# Altium schematic sheet ARK_CAN_GPS_External_Interfaces_Power.SchDoc(ARK_CAN_GPS_External_Interfaces_Power)
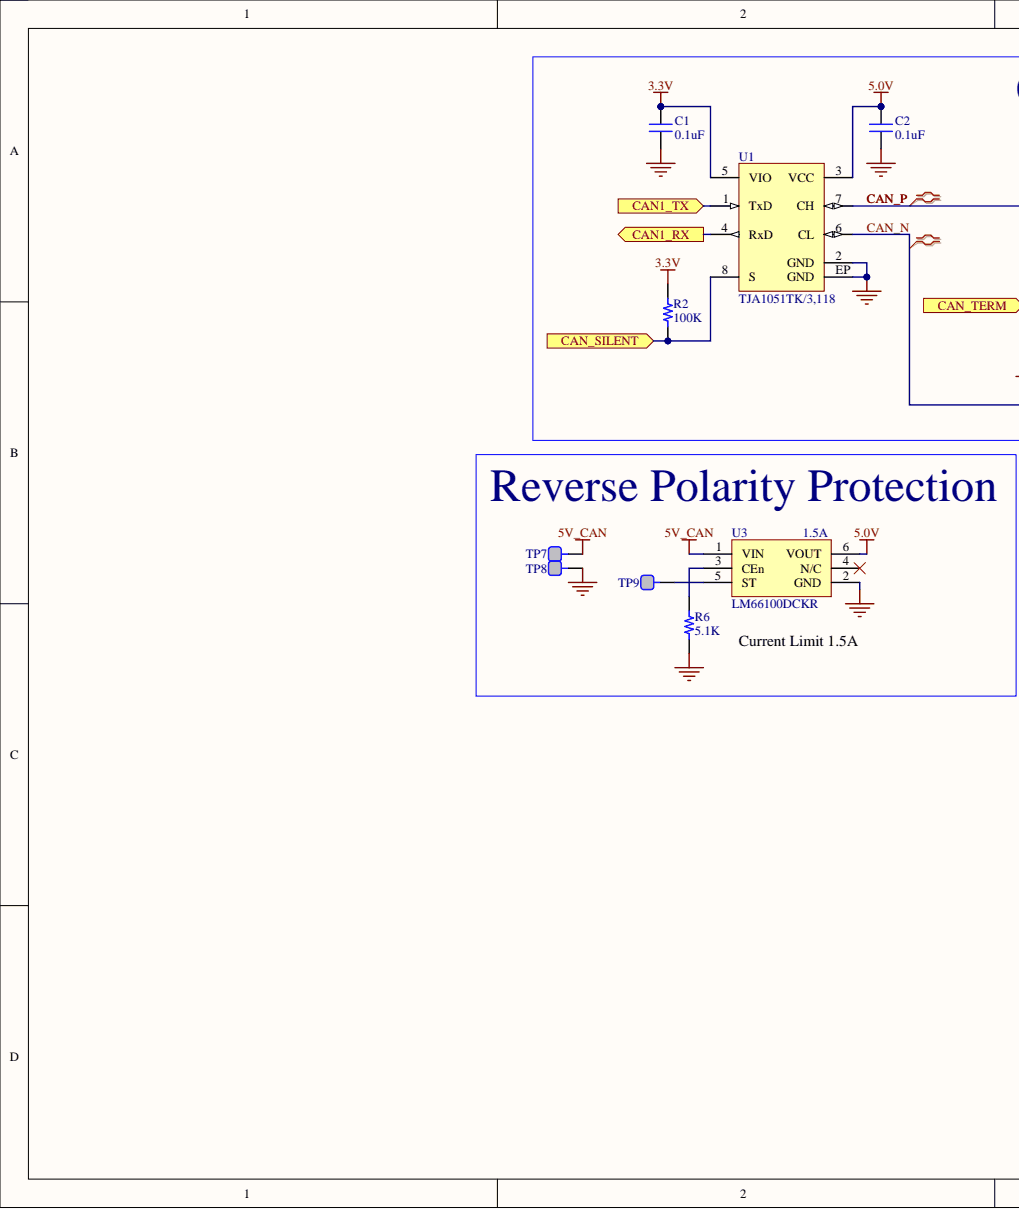
[diagram p0: ARK_CAN_GPS_External_Interfaces_Power.SchDoc, left]
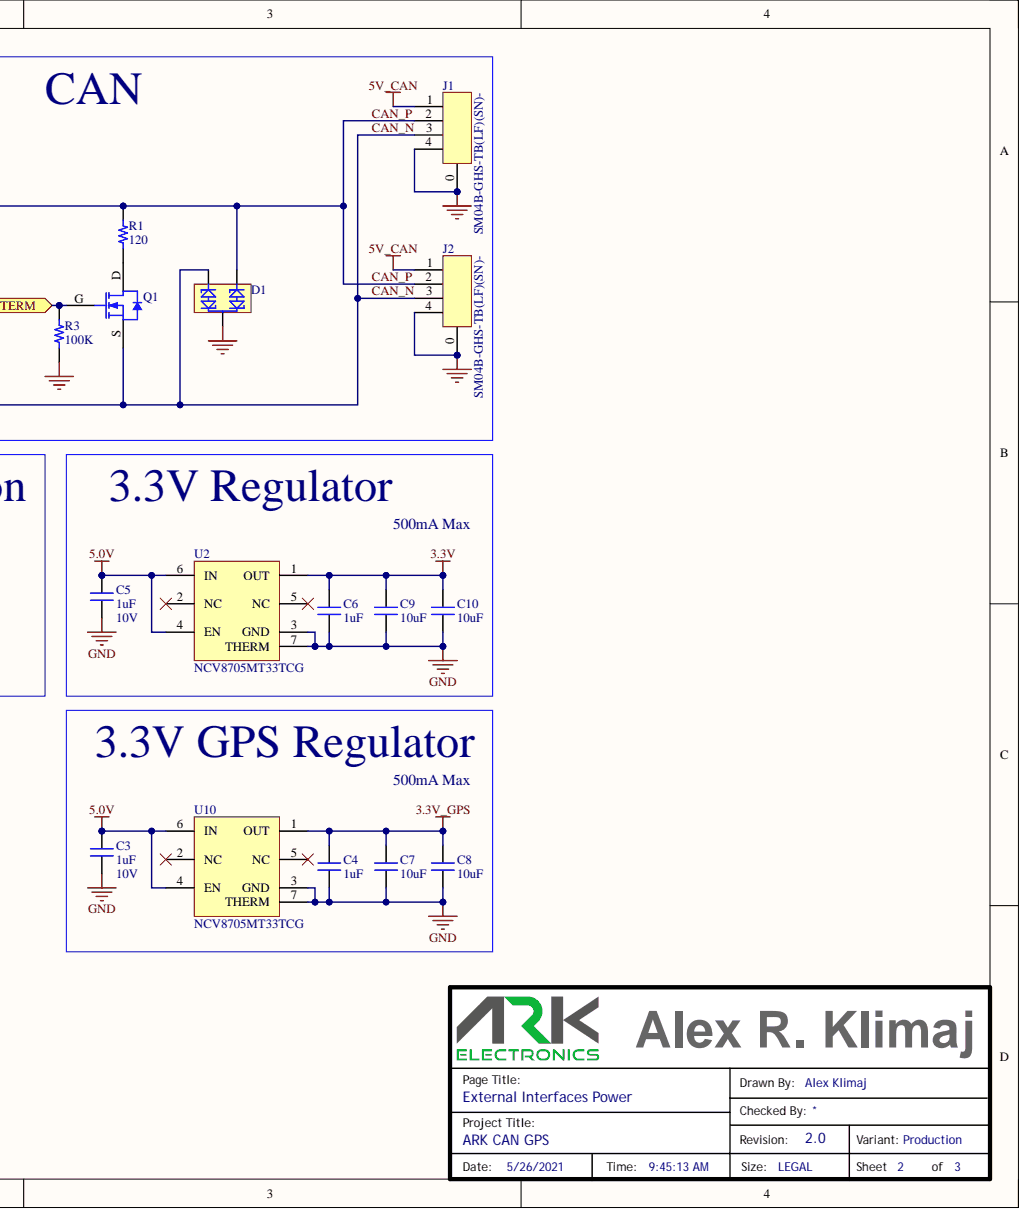
[diagram p1: ARK_CAN_GPS_External_Interfaces_Power.SchDoc, right]

## Parts
C1  value 0.1uF  [CAP 0402/1005]  (hidden comment: Capacitor)
C2  value 0.1uF  [CAP 0402/1005]  (hidden comment: Capacitor)
C3  1uF  Voltage_Rating: 10V  [CAP 0402/1005]
C4  1uF  [CAP 0402/1005]
C5  1uF  Voltage_Rating: 10V  [CAP 0402/1005]
C6  1uF  [CAP 0402/1005]
C7  10uF  [CAP 0402/1005]
C8  10uF  [CAP 0402/1005]
C9  10uF  [CAP 0402/1005]
C10  10uF  [CAP 0402/1005]
D1  PESD1CAN-UX  [SOT65P210X110-3N]  (value not drawn)
J1  SM04B-GHS  value SM04B-GHS-TB(LF)(SN)-  Mfr Part Number: SM04B-GHS-TB(LF)(SN)-  [SM04B-GHS]
J2  SM04B-GHS  value SM04B-GHS-TB(LF)(SN)-  Mfr Part Number: SM04B-GHS-TB(LF)(SN)-  [SM04B-GHS]
Q1  PMV130ENEAR  [SOT23 DIODES]  (value not drawn)
R1  120  [RES603L]
R2  100K  [RESC0402(1005)_N]
R3  100K  [RESC0402(1005)_N]
R6  value 5.1K  [RESC0402(1005)_N]  (hidden comment: Resistor)
TP7  TEST_PAD  [TEST_PAD]  (value not drawn)  (no BOM)
TP8  TEST_PAD  [TEST_PAD]  (value not drawn)  (no BOM)
TP9  TEST_PAD  [TEST_PAD]  (value not drawn)  (no BOM)
U1  TJA1051TK/3,118  [8DFN_3x3]
U2  NCV8705MT33TCG  [WDFN6 2x2, 0.65P]
U3  LM66100  value LM66100DCKR  Current_Rating: 1.5A  Mfr Part Number: LM66100DCKR  [SOT65P210X110-6N]
U10  NCV8705MT33TCG  [WDFN6 2x2, 0.65P]

## Ports
CAN1_RX, CAN1_TX, CAN_SILENT, CAN_TERM

## Nets
3.3V: C1.2 C6.2 C9.2 C10.2 R2.2 U1.5(VIO) U2.1(OUT)
3.3V_GPS: C4.2 C7.2 C8.2 U10.1(OUT)
5.0V: C2.2 C3.2 C5.2 J1.1 J2.1 TP7.1 U1.3(VCC) U2.4(EN) U2.6(IN) U3.1(VIN) U3.6(VOUT) U10.4(EN) U10.6(IN)
GND: C1.1 C2.1 C3.1 C4.1 C5.1 C6.1 C7.1 C8.1 C9.1 C10.1 D1.3 J1.0 J1.4 J2.0 J2.4 R3.1 R6.2 TP8.1 U1.2(GND) U1.EP(GND) U2.3(GND) U2.7(THERM) U3.2(GND) U10.3(GND) U10.7(THERM)
CAN1_RX: U1.4(RxD) ; port CAN1_RX
CAN1_TX: U1.1(TxD) ; port CAN1_TX
CAN_N: D1.1 J1.3 J2.3 Q1.S U1.6(CL)
CAN_P: D1.2 J1.2 J2.2 R1.2 U1.7(CH)
CAN_SILENT: R2.1 U1.8(S) ; port CAN_SILENT
CAN_TERM: Q1.G R3.2 ; port CAN_TERM
NetQ1_D: Q1.D R1.1
NetR6_1: R6.1 U3.3(CEn)
NetTP9_1: TP9.1 U3.5(ST)

Not connected: U2 2(NC) 5(NC); U3 4(N/C); U10 2(NC) 5(NC)

## Library data of the parts
C1 C2 = 30303: SMT Capacitor; Manufacturer: Samsung Electro-Mechanics; Mfr Part Description: CAP CER 0.1uF ±10% 10V X5R 0402 (1005 Metric); Mfr Part Number: CL05A104KP5NNNC; Database Table Name: Parts; Dielectric: X5R; Tolerance: ±10%; Updated By: aklimaj; Voltage_Rating: 10V; Component Kind: Standard; ComponentLink1Description: Datasheet; ComponentLink1URL: http://www.samsungsem.com/kr/support/product-search/mlcc/CL05A104KP5NNNC.jsp; ComponentLink2Description: Supplier Part Details; ComponentLink2URL: https://www.digikey.com/product-detail/en/samsung-electro-mechanics/CL05A104KP5NNNC/1276-1022-1-ND/3889108; Created_Date: 2019/02/28 17:08:36; ID: 30303; Library Name: Parts.DbLib; LifeCycle: New; Package_Case: 0402 (1005 Metric); Part_Type: Capacitor; RoHS: Yes; Supplier: Digi-Key; Supplier Part Number: 1276-1022-1-ND; Temperature Range: -55C to +85C; Updated_Date: 2019/02/28 17:09:37; Created By: aklimaj
C3 C5 = 30652: SMT Capacitor; Manufacturer: Samsung Electro-Mechanics; Mfr Part Description: CAP CER 1uF ±10% 10V X5R 0402 (1005 Metric); Mfr Part Number: CL05A105KP5NNNC; Database Table Name: Parts; Dielectric: X5R; Tolerance: ±10%; Updated By: aklimaj; Component Kind: Standard; ComponentLink1Description: Datasheet; ComponentLink1URL: http://www.samsungsem.com/kr/support/product-search/mlcc/CL05A105KP5NNNC.jsp; ComponentLink2Description: Supplier Part Details; ComponentLink2URL: https://www.digikey.com/product-detail/en/0/1276-1076-1-ND; Created_Date: 2019/11/21 13:38:55; ID: 30652; Library Name: Parts.DbLib; LifeCycle: New; Package_Case: 0402 (1005 Metric); Part_Type: Capacitor; RoHS: Yes; Supplier: Digi-Key; Supplier Part Number: 1276-1076-1-ND; Temperature Range: -55C to +85C; Updated_Date: 2020/08/31 12:38:02; Value: 1uF; Created By: aklimaj
C4 C6 = 30652: SMT Capacitor; Manufacturer: Samsung Electro-Mechanics; Mfr Part Description: CAP CER 1uF ±10% 10V X5R 0402 (1005 Metric); Mfr Part Number: CL05A105KP5NNNC; Database Table Name: Parts; Dielectric: X5R; Tolerance: ±10%; Updated By: aklimaj; Voltage_Rating: 10V; Component Kind: Standard; ComponentLink1Description: Datasheet; ComponentLink1URL: http://www.samsungsem.com/kr/support/product-search/mlcc/CL05A105KP5NNNC.jsp; ComponentLink2Description: Supplier Part Details; ComponentLink2URL: https://www.digikey.com/product-detail/en/0/1276-1076-1-ND; Created_Date: 2019/11/21 13:38:55; ID: 30652; Library Name: Parts.DbLib; LifeCycle: New; Package_Case: 0402 (1005 Metric); Part_Type: Capacitor; RoHS: Yes; Supplier: Digi-Key; Supplier Part Number: 1276-1076-1-ND; Temperature Range: -55C to +85C; Updated_Date: 2020/08/31 12:38:02; Value: 1uF; Created By: aklimaj
C7-C10 = 30418: SMT Capacitor; Manufacturer: Samsung Electro-Mechanics; Mfr Part Description: CAP CER 10uF ±20% 10V X5R 0402 (1005 Metric); Mfr Part Number: CL05A106MP8NUB8; Database Table Name: Parts; Dielectric: X5R; Tolerance: ±20%; Updated By: aklimaj; Voltage_Rating: 10V; Component Kind: Standard; ComponentLink1Description: Datasheet; ComponentLink1URL: http://www.samsungsem.com/kr/support/product-search/mlcc/CL05A106MP8NUB8.jsp; ComponentLink2Description: Supplier Part Details; ComponentLink2URL: https://www.digikey.com/product-detail/en/samsung-electro-mechanics/CL05A106MP8NUB8/1276-6830-1-ND/5961689; Created_Date: 2019/04/03 13:12:18; ID: 30418; Library Name: Parts.DbLib; LifeCycle: New; Package_Case: 0402 (1005 Metric); Part_Type: Capacitor; RoHS: Yes; Supplier: Digi-Key; Supplier Part Number: 1276-6830-1-ND; Temperature Range: -55C to +85C; Updated_Date: 2019/04/03 13:12:36; Value: 10uF; Created By: aklimaj
D1 = 30765: Manufacturer: Nexperia USA Inc.; Mfr Part Description: TVS DIODE 24V 50V SC70-3; Part_Type: Protection; Current_Rating: 3A (8/20µs); Database Table Name: Parts; Updated By: aklimaj; Voltage_Rating: 24V (Max); Component Kind: Standard; ComponentLink1Description: Datasheet; ComponentLink1URL: https://assets.nexperia.com/documents/data-sheet/PESD1CAN-U.pdf; ComponentLink2Description: Supplier Part Details; ComponentLink2URL: https://www.digikey.com/product-detail/en/nexperia-usa-inc/PESD1CAN-UX/1727-1306-1-ND/4386316; Created_Date: 2020/04/14 12:26:16; ID: 30765; Library Name: Parts.DbLib; LifeCycle: New; Mfr Part Number: PESD1CAN-UX; Package_Case: SC-70, SOT-323; RoHS: Yes; Supplier: Digi-Key; Supplier Part Number: 1727-1306-1-ND; Temperature Range: -55C to +150C (TA); Updated_Date: 2020/04/14 12:34:02; Value: PESD1CAN-UX; Created By: aklimaj
J1 J2 = 30106: CONN HEADER GH SIDE 4POS 1.25MM; Manufacturer: JST Sales America Inc.; Part_Type: Connector; Current_Rating: 1A; Database Table Name: Parts; Updated By: aklimaj; Voltage_Rating: 50V; Component Kind: Standard; ComponentLink1Description: Datasheet; ComponentLink1URL: http://www.jst-mfg.com/product/pdf/eng/eGH.pdf; ComponentLink2Description: Supplier Part Details; ComponentLink2URL: https://www.digikey.com/product-detail/en/jst-sales-america-inc/SM04B-GHS-TB-LF-SN/455-1566-1-ND/807834; Created_Date: 2017/09/21 14:10:07; ID: 30106; Library Name: Parts.DbLib; LifeCycle: New; Mfr Part Description: CONN HEADER GH SIDE 4POS 1.25MM; RoHS: Yes; Supplier: Digi-Key; Supplier Part Number: 455-1566-1-ND; Temperature Range: -25C to +85C; Updated_Date: 2018/10/19 21:38:27; Created By: aklimaj
Q1 = 31093: Manufacturer: Nexperia USA Inc.; Mfr Part Description: MOSFET N-CH 40V 2.1A TO236AB; Part_Type: Discrete; Current_Rating: 2.1A (Ta); Database Table Name: Parts; Updated By: aklimaj; Voltage_Rating: 4.5V, 10V; Component Kind: Standard; ComponentLink1Description: Datasheet; ComponentLink1URL: https://assets.nexperia.com/documents/data-sheet/PMV130ENEA.pdf; ComponentLink2Description: Supplier Part Details; ComponentLink2URL: https://www.digikey.com/en/products/detail/nexperia-usa-inc/PMV130ENEAR/5395790; Created_Date: 2021/05/26 09:10:32; ID: 31093; Library Name: Parts.DbLib; LifeCycle: New; Mfr Part Number: PMV130ENEAR; Package_Case: TO-236-3, SC-59, SOT-23-3; RoHS: Yes; Supplier: Digi-Key; Supplier Part Number: 1727-2299-2-ND; Temperature Range: -55C to +150C (TJ); Updated_Date: 2021/05/26 09:10:42; Value: PMV130ENEAR; Created By: aklimaj
R1 = 30956: SMT Resistor; Manufacturer: Stackpole Electronics Inc; Mfr Part Description: RES 120 Ohm ±1% 1/10W 0603 (1608 Metric); Mfr Part Number: RMCF0603FT120R; Database Table Name: Parts; Power_Rating: 0.1W, 1/10W; Temperature Coeff: ±100ppm/degC; Tolerance: ±1%; Updated By: aklimaj; Component Kind: Standard; ComponentLink1Description: Datasheet; ComponentLink1URL: https://www.seielect.com/catalog/sei-rmcf_rmcp.pdf; ComponentLink2Description: Supplier Part Details; ComponentLink2URL: https://www.digikey.com/products/en?keywords=RMCF0603FT120R; Created_Date: 2020/12/23 11:13:51; ID: 30956; Library Name: Parts.DbLib; LifeCycle: New; Package_Case: 0603 (1608 Metric); Part_Type: Resistor; RoHS: Yes; Supplier: Digi-Key; Supplier Part Number: RMCF0603FT120RTR-ND; Temperature Range: -55C to +155C; Updated_Date: 2020/12/23 11:14:13; Value: 120; Created By: aklimaj
R2 R3 = 30333: SMT Resistor; Manufacturer: Yageo; Mfr Part Description: RES 100K Ohm ±5% 1/16W 0402 (1005 Metric); Mfr Part Number: AC0402JR-07100KL; Database Table Name: Parts; Power_Rating: 0.063W, 1/16W; Temperature Coeff: ±100ppm/degC; Tolerance: ±5%; Updated By: aklimaj; Component Kind: Standard; ComponentLink1Description: Datasheet; ComponentLink1URL: http://www.yageo.com/NewPortal/yageodocoutput?fileName=/pdf/R-Chip/PYu-AC_51_RoHS_L_6.pdf; ComponentLink2Description: Supplier Part Details; ComponentLink2URL: https://www.digikey.com/products/en?keywords=YAG3515CT-ND&stock=1; Created_Date: 2019/02/28 18:55:55; ID: 30333; Library Name: Parts.DbLib; LifeCycle: New; Package_Case: 0402 (1005 Metric); Part_Type: Resistor; RoHS: Yes; Supplier: Digi-Key; Supplier Part Number: YAG3515CT-ND; Temperature Range: -55C to +155C; Updated_Date: 2019/02/28 18:56:10; Value: 100K; Created By: aklimaj
R6 = 30207: SMT Resistor; Manufacturer: Yageo; Mfr Part Description: RES 5.1K Ohm ±1% 1/16W 0402 (1005 Metric); Mfr Part Number: RC0402FR-075K1L; Database Table Name: Parts; Power_Rating: 0.063W, 1/16W; Temperature Coeff: ±100ppm/degC; Tolerance: ±1%; Updated By: aklimaj; Component Kind: Standard; ComponentLink1Description: Datasheet; ComponentLink1URL: http://www.yageo.com/documents/recent/PYu-RC_Group_51_RoHS_L_9.pdf; ComponentLink2Description: Supplier Part Details; ComponentLink2URL: https://www.digikey.com/product-detail/en/yageo/RC0402FR-075K1L/311-5.10KLRCT-ND/729571; Created_Date: 2018/05/21 18:16:47; ID: 30207; Library Name: Parts.DbLib; LifeCycle: New; Package_Case: 0402 (1005 Metric); Part_Type: Resistor; RoHS: Yes; Supplier: Digi-Key; Supplier Part Number: 311-5.10KLRCT-ND; Temperature Range: -55C to +155C; Updated_Date: 2018/10/19 21:38:02; Created By: aklimaj
TP7-TP9 = 30015: Mfr Part Description: Test Point; Database Table Name: Parts; Updated By: aklimaj; ComponentLink1Description: Datasheet; ComponentLink2Description: Supplier Part Details; Created_Date: 2017/07/13 09:26:35; Description: TEST_PAD; ID: 30015; Library Name: Parts.DbLib; LifeCycle: New; Part_Type: Test Point; RoHS: Yes; Updated_Date: 2019/07/19 12:21:04; Created By: aklimaj
U1 = 30095: IC CAN TRANSEIVER HS 8HVSON; Manufacturer: NXP USA Inc.; Database Table Name: Parts; Updated By: aklimaj; Component Kind: Standard; ComponentLink1Description: Datasheet; ComponentLink1URL: http://www.nxp.com/documents/data_sheet/TJA1051.pdf; ComponentLink2Description: Supplier Part Details; ComponentLink2URL: https://www.digikey.com/product-detail/en/nxp-usa-inc/TJA1051TK-3,118/568-8686-1-ND/2803572; Created_Date: 2017/09/13 12:36:12; ID: 30095; Library Name: Parts.DbLib; LifeCycle: New; Mfr Part Description: IC CAN TRANSEIVER HS 8HVSON; Mfr Part Number: TJA1051TK/3,118; Package_Case: 8-VDFN Exposed Pad; Part_Type: IC; RoHS: Yes; Supplier: Digi-Key; Supplier Part Number: 568-8686-1-ND; Temperature Range: -40C to +150C; Updated_Date: 2018/10/19 21:38:29; Value: TJA1051TK/3,118; Created By: aklimaj
U2 U10 = 30451: Manufacturer: ON Semiconductor; Mfr Part Description: IC REG LINEAR 3.3V 500MA 6WDFN; Part_Type: IC; Database Table Name: Parts; Sub_Type: REG; Updated By: aklimaj; Component Kind: Standard; ComponentLink1Description: Datasheet; ComponentLink1URL: http://www.onsemi.com/pub/Collateral/NCV8705-D.PDF; ComponentLink2Description: Supplier Part Details; ComponentLink2URL: https://www.digikey.com/product-detail/en/on-semiconductor/NCV8705MT33TCG/NCV8705MT33TCGOSCT-ND/8538675; Created_Date: 2019/05/08 11:35:43; ID: 30451; Library Name: Parts.DbLib; LifeCycle: New; Mfr Part Number: NCV8705MT33TCG; Package_Case: 6-WDFN Exposed Pad; RoHS: Yes; Supplier: Digi-Key; Supplier Part Number: NCV8705MT33TCGOSCT-ND; Temperature Range: -40C to +125C (TJ); Updated_Date: 2019/05/08 11:48:17; Value: NCV8705MT33TCG; Created By: aklimaj
U3 = 30951: Manufacturer: Texas Instruments; Mfr Part Description: IC OR CTRLR IDEAL DIODE SC70-6; Part_Type: IC; Database Table Name: Parts; Updated By: aklimaj; Voltage_Rating: 5.5V; Component Kind: Standard; ComponentLink1Description: Datasheet; ComponentLink1URL: http://www.ti.com/general/docs/suppproductinfo.tsp?distId=10&amp;gotoUrl=http%3A%2F%2Fwww.ti.com%2Flit%2Fgpn%2flm66100; ComponentLink2Description: Supplier Part Details; ComponentLink2URL: https://www.digikey.com/products/en?keywords=LM66100DCKR; Created_Date: 2020/12/22 17:48:20; ID: 30951; Library Name: Parts.DbLib; LifeCycle: New; Package_Case: 6-TSSOP, SC-88, SOT-363; RoHS: Yes; Supplier: Digi-Key; Supplier Part Number: 296-53541-2-ND; Temperature Range: -40C to +105C; Updated_Date: 2020/12/22 17:55:00; Created By: aklimaj
